# Altium schematic sheet LCL.SchDoc
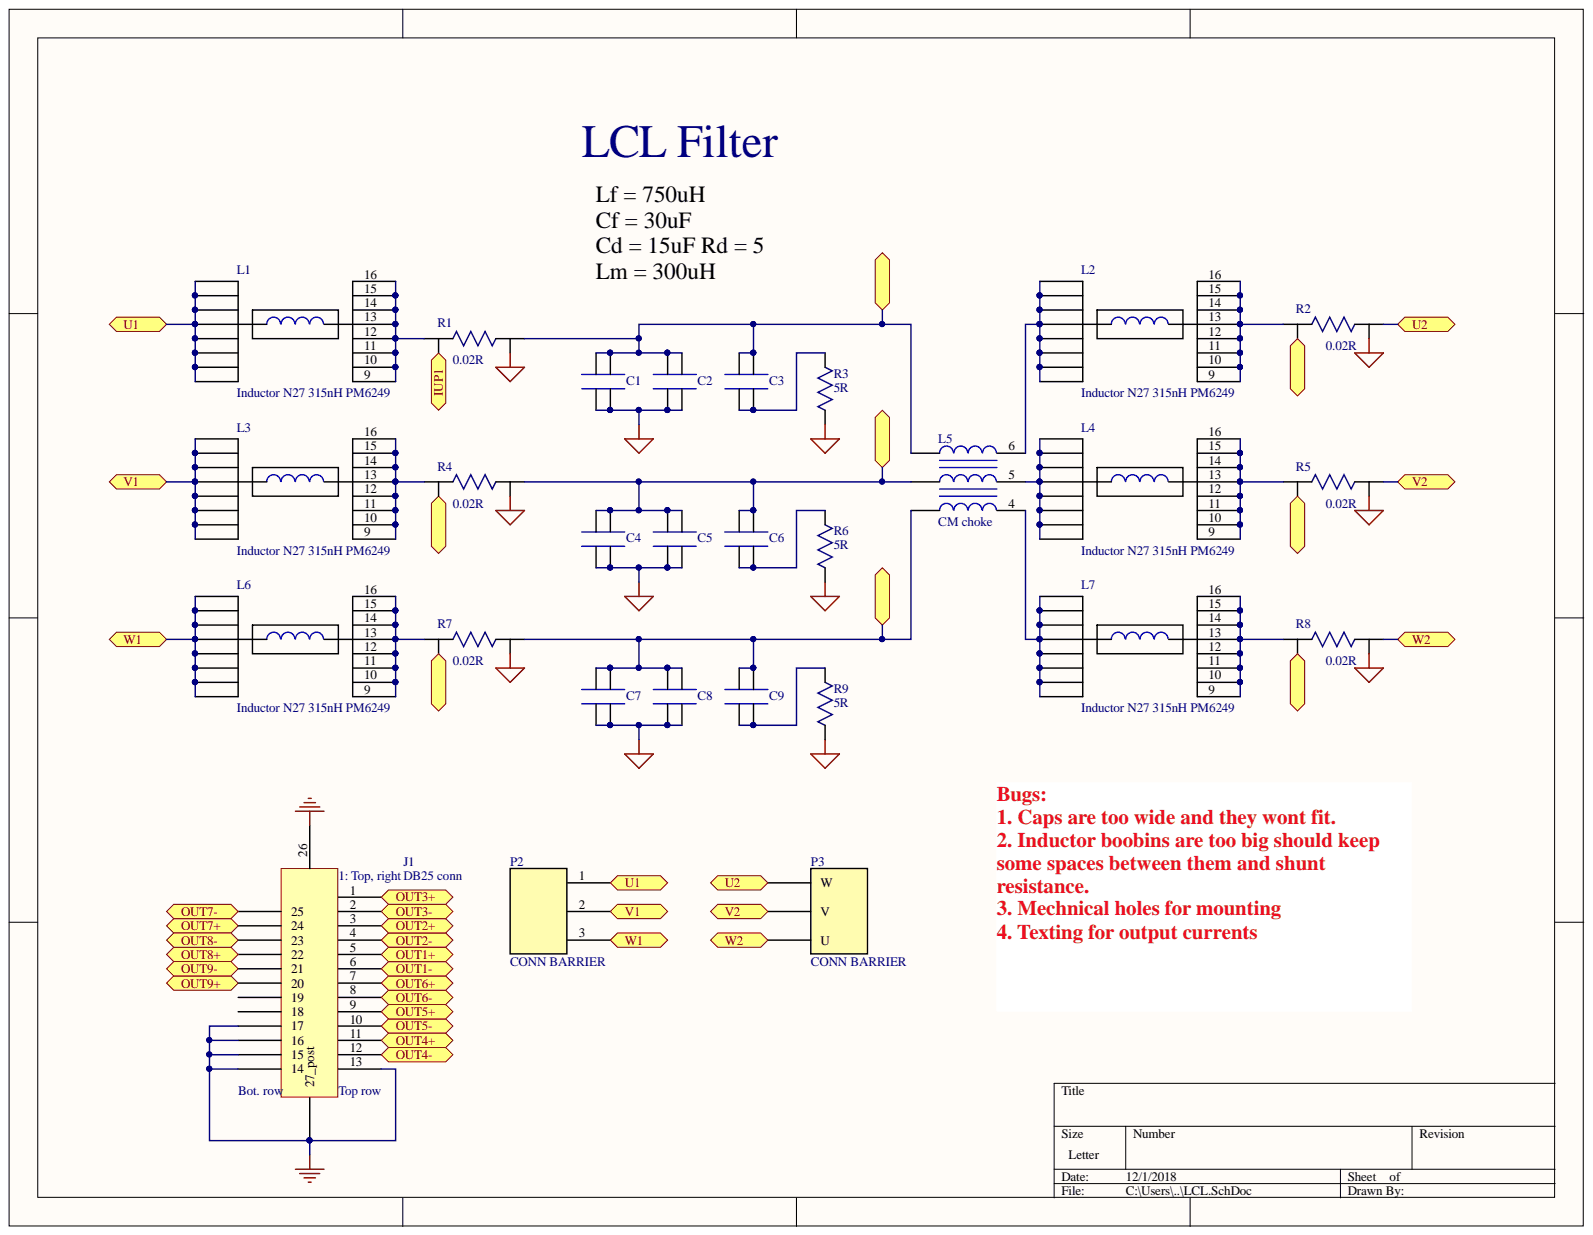
[diagram p0: LCL.SchDoc]

## Parts
C1  (no value)  [Radial - 4 Leads]
C2  (no value)  [Radial - 4 Leads]
C3  (no value)  [Radial - 4 Leads]
C4  (no value)  [Radial - 4 Leads]
C5  (no value)  [Radial - 4 Leads]
C6  (no value)  [Radial - 4 Leads]
C7  (no value)  [Radial - 4 Leads]
C8  (no value)  [Radial - 4 Leads]
C9  (no value)  [Radial - 4 Leads]
J1  DB25 conn  [Connector D-Sub Receptacle 25 pos]
L1  Inductor N27 315nH PM6249  [IND_PM6249]
L2  Inductor N27 315nH PM6249  [IND_PM6249]
L3  Inductor N27 315nH PM6249  [IND_PM6249]
L4  Inductor N27 315nH PM6249  [IND_PM6249]
L5  CM choke  [CM Choke]
L6  Inductor N27 315nH PM6249  [IND_PM6249]
L7  Inductor N27 315nH PM6249  [IND_PM6249]
P2  CONN BARRIER  [Terminal Barrier 3PIN]
P3  CONN BARRIER  [Terminal Barrier 3PIN]
R1  value 0.02R  [Res Precision CSM3637Z]  (hidden comment: Resistor Precision)
R2  value 0.02R  [Res Precision CSM3637Z]  (hidden comment: Resistor Precision)
R3  value 5R  [Axial 50mm]  (hidden comment: Resistor Power)
R4  value 0.02R  [Res Precision CSM3637Z]  (hidden comment: Resistor Precision)
R5  value 0.02R  [Res Precision CSM3637Z]  (hidden comment: Resistor Precision)
R6  value 5R  [Axial 50mm]  (hidden comment: Resistor Power)
R7  value 0.02R  [Res Precision CSM3637Z]  (hidden comment: Resistor Precision)
R8  value 0.02R  [Res Precision CSM3637Z]  (hidden comment: Resistor Precision)
R9  value 5R  [Axial 50mm]  (hidden comment: Resistor Power)

## Ports
IUP1, IUP2, IVP1, IVP2, IWP1, IWP2, OUT1+, OUT1-, OUT2+, OUT2-, OUT3+, OUT3-, OUT4+, OUT4-, OUT5+, OUT5-, OUT6+, OUT6-, OUT7+, OUT7-, OUT8+, OUT8-, OUT9+, OUT9-, U1, U2, V1, V2, VU, VV, VW, W1, W2

## Nets
GND_LV: J1.13 J1.14 J1.15 J1.16 J1.17 J1.26 J1.27(27_post)
GND_USH1: R1.2
GND_USH2: R2.2
GND_VSH1: R4.2
GND_VSH2: R5.2
GND_WSH1: R7.2
GND_WSH2: R8.2
N_HV: C1.1 C1.2 C2.1 C2.2 C4.1 C4.2 C5.1 C5.2 C7.1 C7.2 C8.1 C8.2 R3.1 R6.1 R9.1
IUP1: R1.3 ; port IUP1
IUP2: R2.3 ; port IUP2
IVP1: R4.3 ; port IVP1
IVP2: R5.3 ; port IVP2
IWP1: R7.3 ; port IWP1
IWP2: R8.3 ; port IWP2
U1: L1.1 L1.2 L1.3 L1.4 L1.5 L1.6 L1.7 L1.8 P2.1 ; port U1
U2: P3.3 R2.1 ; port U2
V1: L3.1 L3.2 L3.3 L3.4 L3.5 L3.6 L3.7 L3.8 P2.2 ; port V1
V2: P3.2 R5.1 ; port V2
VU: C1.3 C1.4 C2.3 C2.4 C3.3 C3.4 L5.1 R1.1 ; port VU
VV: C4.3 C4.4 C5.3 C5.4 C6.3 C6.4 L5.2 R4.1 ; port VV
VW: C7.3 C7.4 C8.3 C8.4 C9.3 C9.4 L5.3 R7.1 ; port VW
W1: L6.1 L6.2 L6.3 L6.4 L6.5 L6.6 L6.7 L6.8 P2.3 ; port W1
W2: P3.1 R8.1 ; port W2
NetC3_1: C3.1 C3.2 R3.2
NetC6_1: C6.1 C6.2 R6.2
NetC9_1: C9.1 C9.2 R9.2
NetJ1_1: J1.1
NetJ1_2: J1.2
NetJ1_3: J1.3
NetJ1_4: J1.4
NetJ1_5: J1.5
NetJ1_6: J1.6
NetJ1_7: J1.7
NetJ1_8: J1.8
NetJ1_9: J1.9
NetJ1_10: J1.10
NetJ1_11: J1.11
NetJ1_12: J1.12
NetJ1_20: J1.20
NetJ1_21: J1.21
NetJ1_22: J1.22
NetJ1_23: J1.23
NetJ1_24: J1.24
NetJ1_25: J1.25
NetL1_9: L1.9 L1.10 L1.11 L1.12 L1.13 L1.14 L1.15 L1.16 R1.4
NetL2_1: L2.1 L2.2 L2.3 L2.4 L2.5 L2.6 L2.7 L2.8 L5.6
NetL2_9: L2.9 L2.10 L2.11 L2.12 L2.13 L2.14 L2.15 L2.16 R2.4
NetL3_9: L3.9 L3.10 L3.11 L3.12 L3.13 L3.14 L3.15 L3.16 R4.4
NetL4_1: L4.1 L4.2 L4.3 L4.4 L4.5 L4.6 L4.7 L4.8 L5.5
NetL4_9: L4.9 L4.10 L4.11 L4.12 L4.13 L4.14 L4.15 L4.16 R5.4
NetL5_4: L5.4 L7.1 L7.2 L7.3 L7.4 L7.5 L7.6 L7.7 L7.8
NetL6_9: L6.9 L6.10 L6.11 L6.12 L6.13 L6.14 L6.15 L6.16 R7.4
NetL7_9: L7.9 L7.10 L7.11 L7.12 L7.13 L7.14 L7.15 L7.16 R8.4

Not connected: J1 18 19

## Library data of the parts
C1-C9 = Cap Film Radial - 4 Leads: Radial - 4 Leads; Part: BC2763-ND; Component Kind: Standard; Library Name: Library_Microgrid.SchLib
J1 = Conn D-Sub Receptacle 25 positions: D-Sub Receptacle 25 Position A35111-ND; Component Kind: Standard; Part: A35111-ND; Library Name: Library_Microgrid.SchLib
L5 = CMC 1.5MH 20A: CMC 1.5MH 20A 3LN TH; Part: B82747E6203A40; Component Kind: Standard; Library Name: Library_Microgrid.SchLib
P2 P3 = Terminal Blocks - Barrier Blocks: CONN BARRIER STRIP 3CIRC 0.325"; Part: WM4246-ND; Component Kind: Standard; Library Name: Library_Microgrid.SchLib
R1 R2 R4 R5 R7 R8 = Resistor Precision: RES SMD 0.02 OHM 0.1% 2W 3637; Part: Y1473-.02CCT-ND; Component Kind: Standard; Library Name: Library_Microgrid.SchLib
R3 R6 R9 = Resistor Power: RES 5.0 OHM 10W 1% WW AXIAL; Part: RSD-5.0RCT-ND; Component Kind: Standard; Library Name: Library_Microgrid.SchLib
L1-L4 L6 L7 = Inductor N27 315nH PM6249: Component Kind: Standard; Description: Inductor N27 315nH PM6249; Library Name: Library_Microgrid.SchLib
